# Altium schematic sheet DBP UEB V1.SchDoc
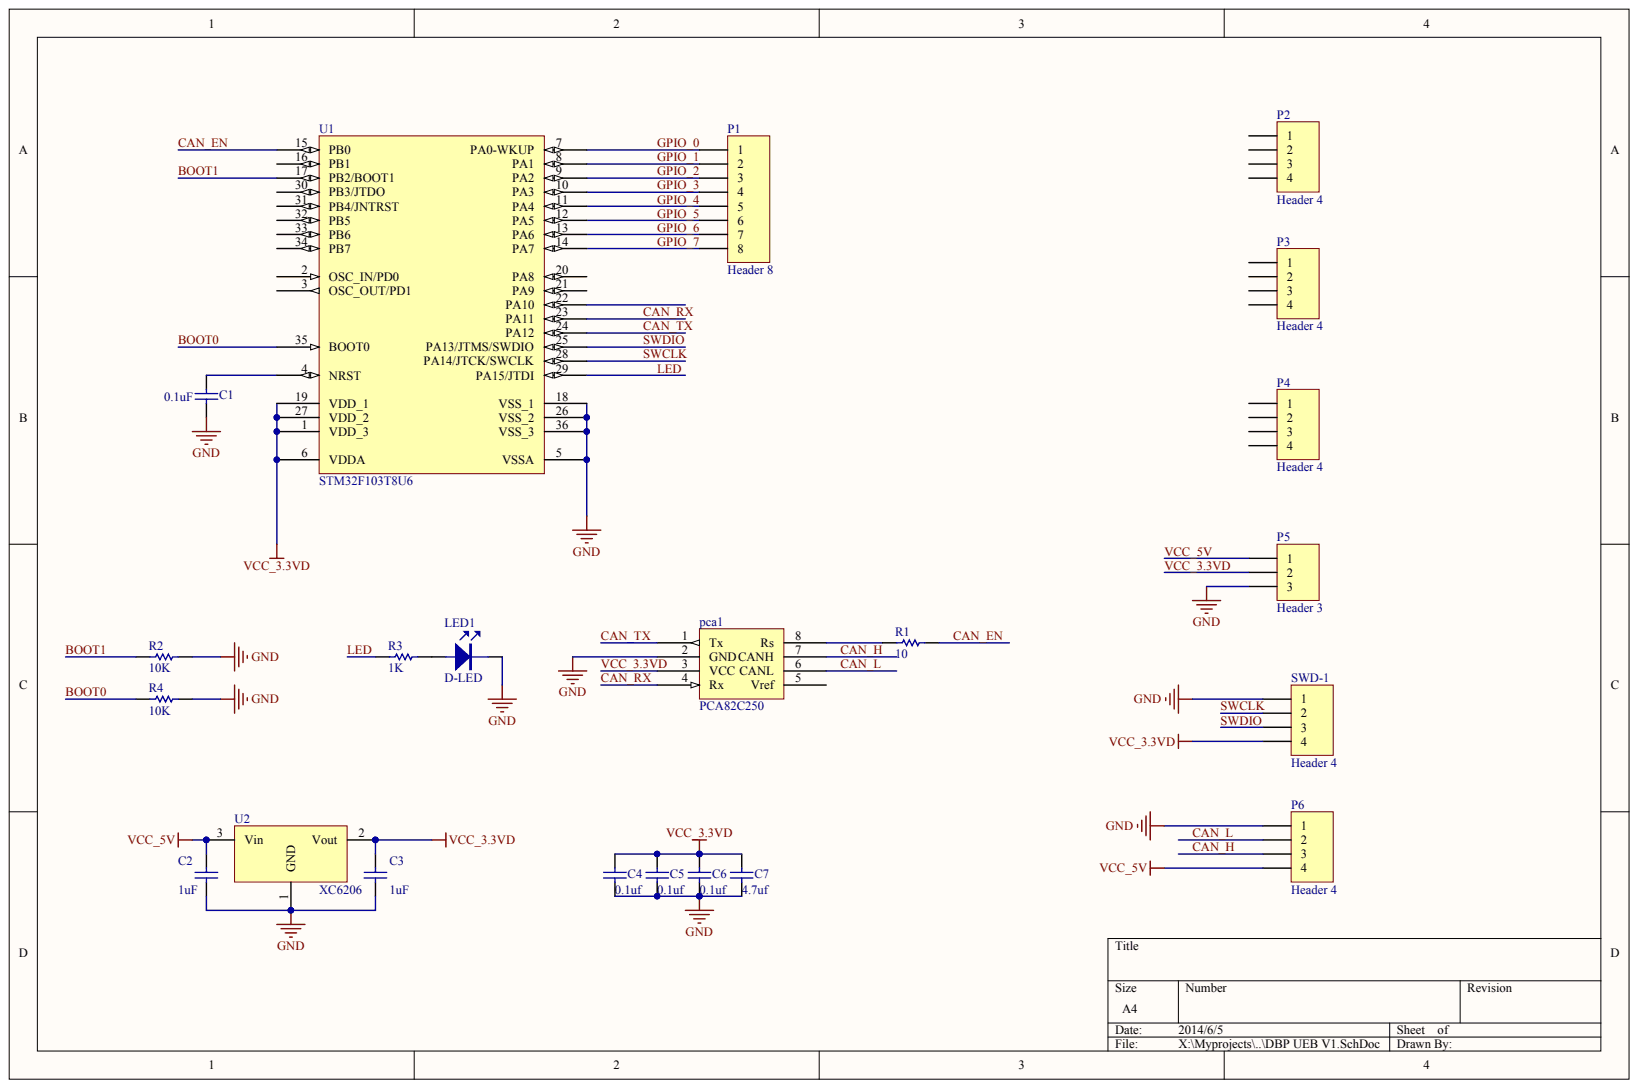
[diagram p0: DBP UEB V1.SchDoc]

## Parts
C1  value 0.1uF  (hidden comment: Cap Semi)
C2  value 1uF  (hidden comment: Cap Semi)
C3  value 1uF  (hidden comment: Cap Semi)
C4  value 0.1uf  (hidden comment: Cap Semi)
C5  value 0.1uf  (hidden comment: Cap Semi)
C6  value 0.1uf  (hidden comment: Cap Semi)
C7  value 4.7uf  (hidden comment: Cap Semi)
LED1  D-LED
P1  Header 8
P2  Header 4
P3  Header 4
P4  Header 4
P5  Header 3
P6  Header 4
pca1  PCA82C250
R1  value 10
R2  value 10K
R3  value 1K  (hidden comment: Res Semi)
R4  value 10K
SWD-1  Header 4
U1  STM32F103T8U6
U2  XC6206

## Nets
GND: C1.2 C2.1 C3.1 C4.1 C5.1 C6.1 C7.1 LED1.K P5.3 P6.1 pca1.2(GND) R2.1 R4.1 SWD-1.1 U1.5(VSSA) U1.18(VSS_1) U1.26(VSS_2) U1.36(VSS_3) U2.1
BOOT0: R4.2 U1.35(BOOT0)
BOOT1: R2.2 U1.17(PB2/BOOT1)
CAN_EN: R1.1 U1.15(PB0)
CAN_H: P6.3 pca1.7(CANH)
CAN_L: P6.2 pca1.6(CANL)
CAN_RX: pca1.4(Rx) U1.23(PA11)
CAN_TX: pca1.1(Tx) U1.24(PA12)
GPIO_0: P1.1 U1.7(PA0-WKUP)
GPIO_1: P1.2 U1.8(PA1)
GPIO_2: P1.3 U1.9(PA2)
GPIO_3: P1.4 U1.10(PA3)
GPIO_4: P1.5 U1.11(PA4)
GPIO_5: P1.6 U1.12(PA5)
GPIO_6: P1.7 U1.13(PA6)
GPIO_7: P1.8 U1.14(PA7)
LED: R3.1 U1.29(PA15/JTDI)
SWCLK: SWD-1.2 U1.28(PA14/JTCK/SWCLK)
SWDIO: SWD-1.3 U1.25(PA13/JTMS/SWDIO)
VCC_3.3VD: C3.2 C4.2 C5.2 C6.2 C7.2 P5.2 pca1.3(VCC) SWD-1.4 U1.1(VDD_3) U1.6(VDDA) U1.19(VDD_1) U1.27(VDD_2) U2.2
VCC_5V: C2.2 P5.1 P6.4 U2.3
NetC1_1: C1.1 U1.4(NRST)
NetLED1_A: LED1.A R3.2
Netpca1_8: pca1.8(Rs) R1.2

Not connected: P2 1 2 3 4; P3 1 2 3 4; P4 1 2 3 4; pca1 5(Vref); U1 2(OSC_IN/PD0) 3(OSC_OUT/PD1) 16(PB1) 20(PA8) 21(PA9) 22(PA10) 30(PB3/JTDO) 31(PB4/JNTRST) 32(PB5) 33(PB6) 34(PB7)

## Library data of the parts
C1-C7 LED1 P1-P6 pca1 R1-R4 SWD-1 U1 U2 = (no symbol name)
  C1-C7: Published: 8-Jun-2000; LatestRevisionDate: 17-Jul-2002; LatestRevisionNote: Re-released for DXP Platform.; PackageReference: C1206
  P1-P6 SWD-1: LatestRevisionDate: 17-Jul-2002; LatestRevisionNote: Re-released for DXP Platform.
  R1-R4: Published: 8-Jun-2000; LatestRevisionDate: 17-Jul-2002; LatestRevisionNote: Re-released for DXP Platform.; PackageReference: AXIAL-0.5
  U1: Published: *; DatasheetVersion: *; PackageVersion: *; PackageReference: *; PackageDescription: *; ComponentLink1Description: *; ComponentLink1URL: *; ComponentLink2Description: *; ComponentLink2URL: *; Publisher: *
  C1-C7 P1-P6 R1-R4 SWD-1: Publisher: Altium Limited
